# Altium schematic sheet SATA & RGB.SchDoc
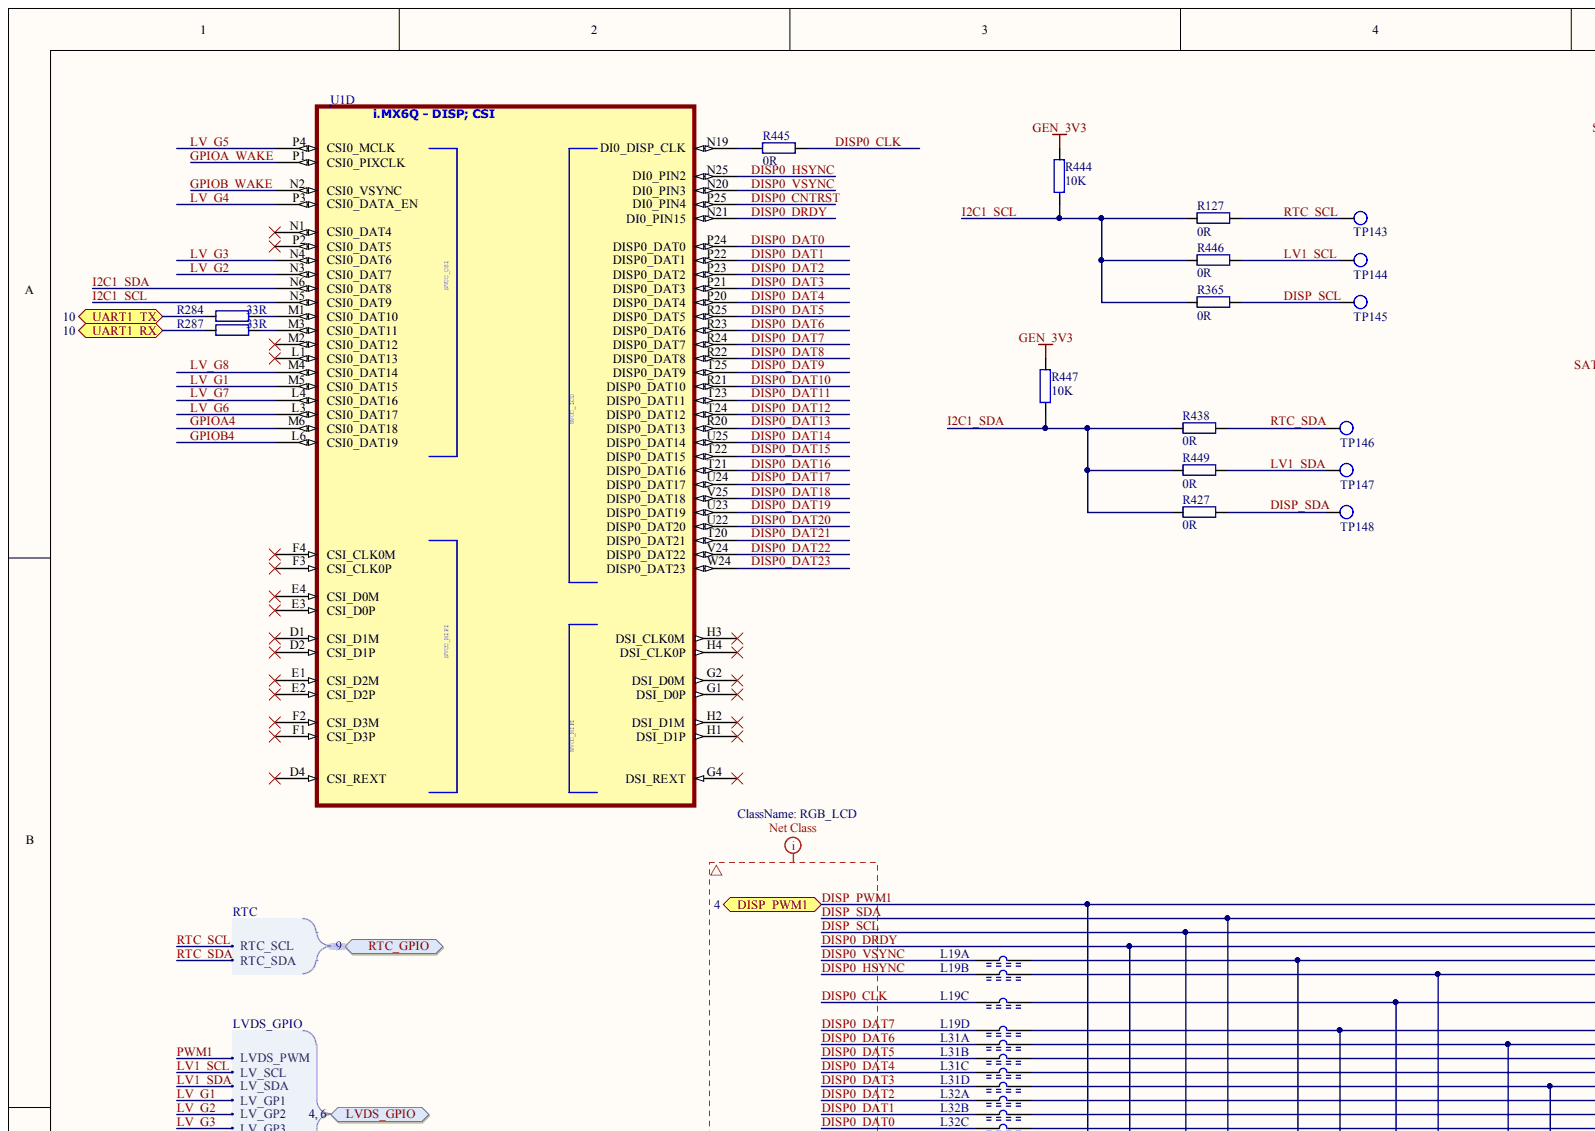
[diagram p0: SATA & RGB.SchDoc, top left]
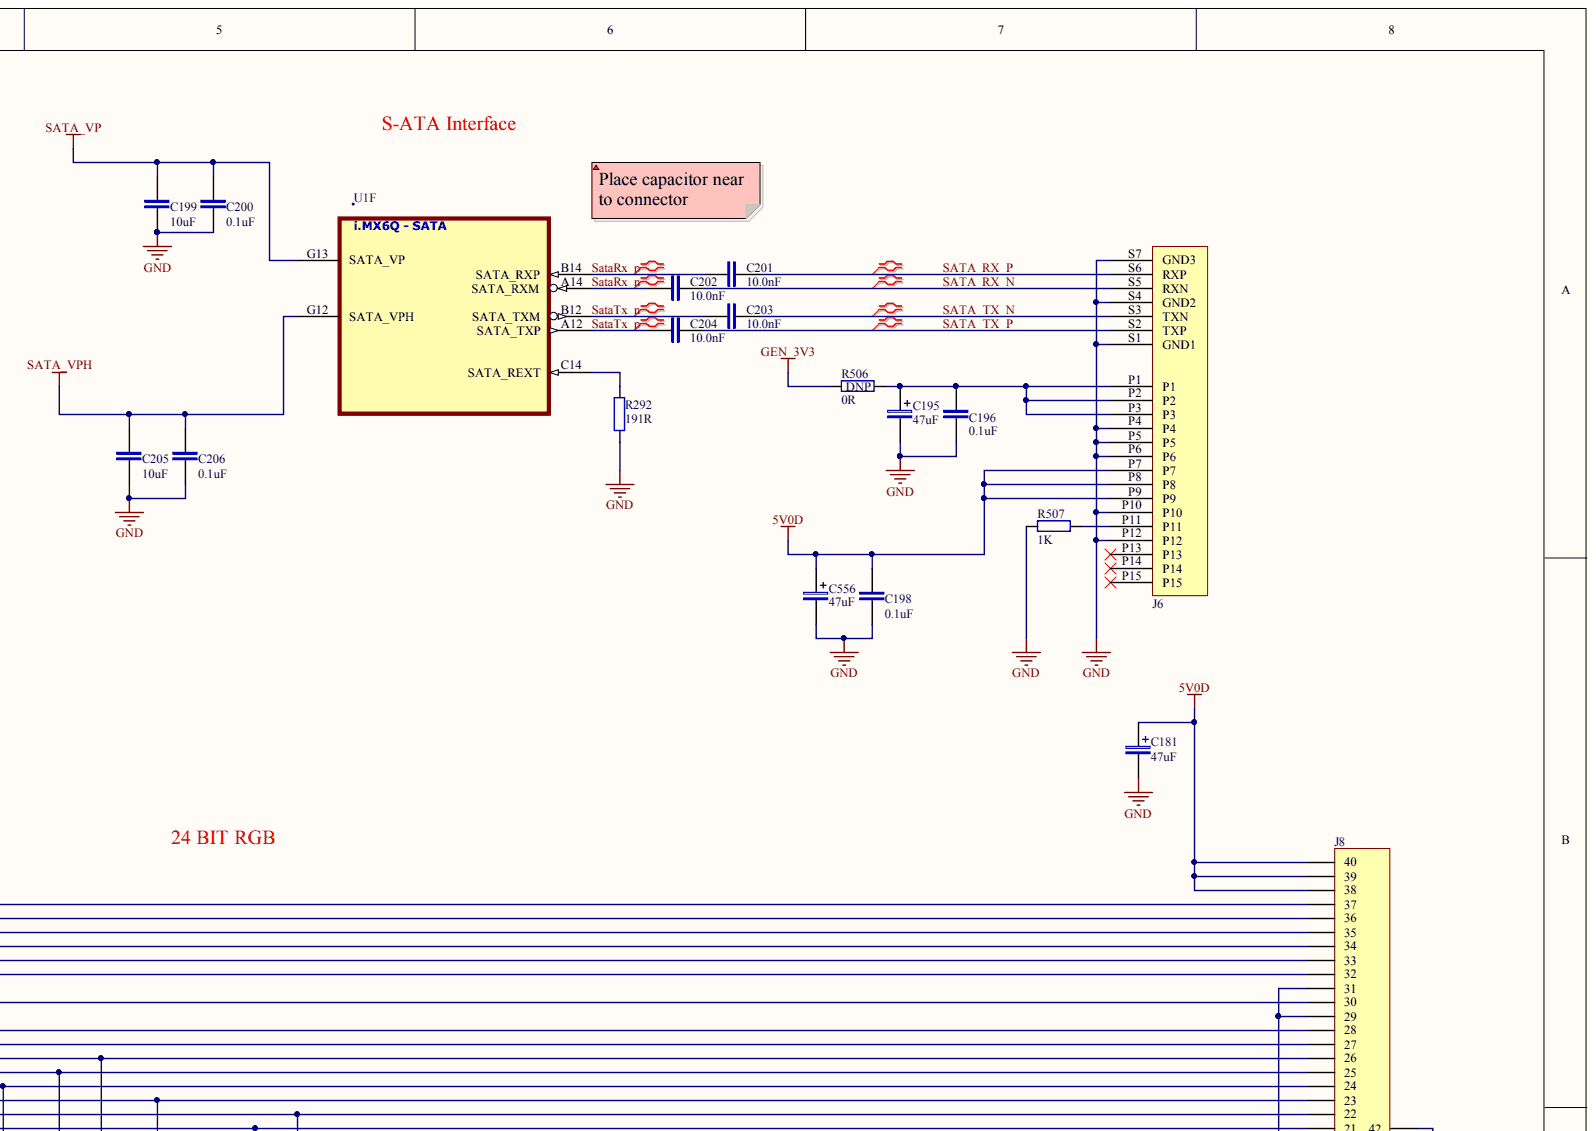
[diagram p1: SATA & RGB.SchDoc, top right]
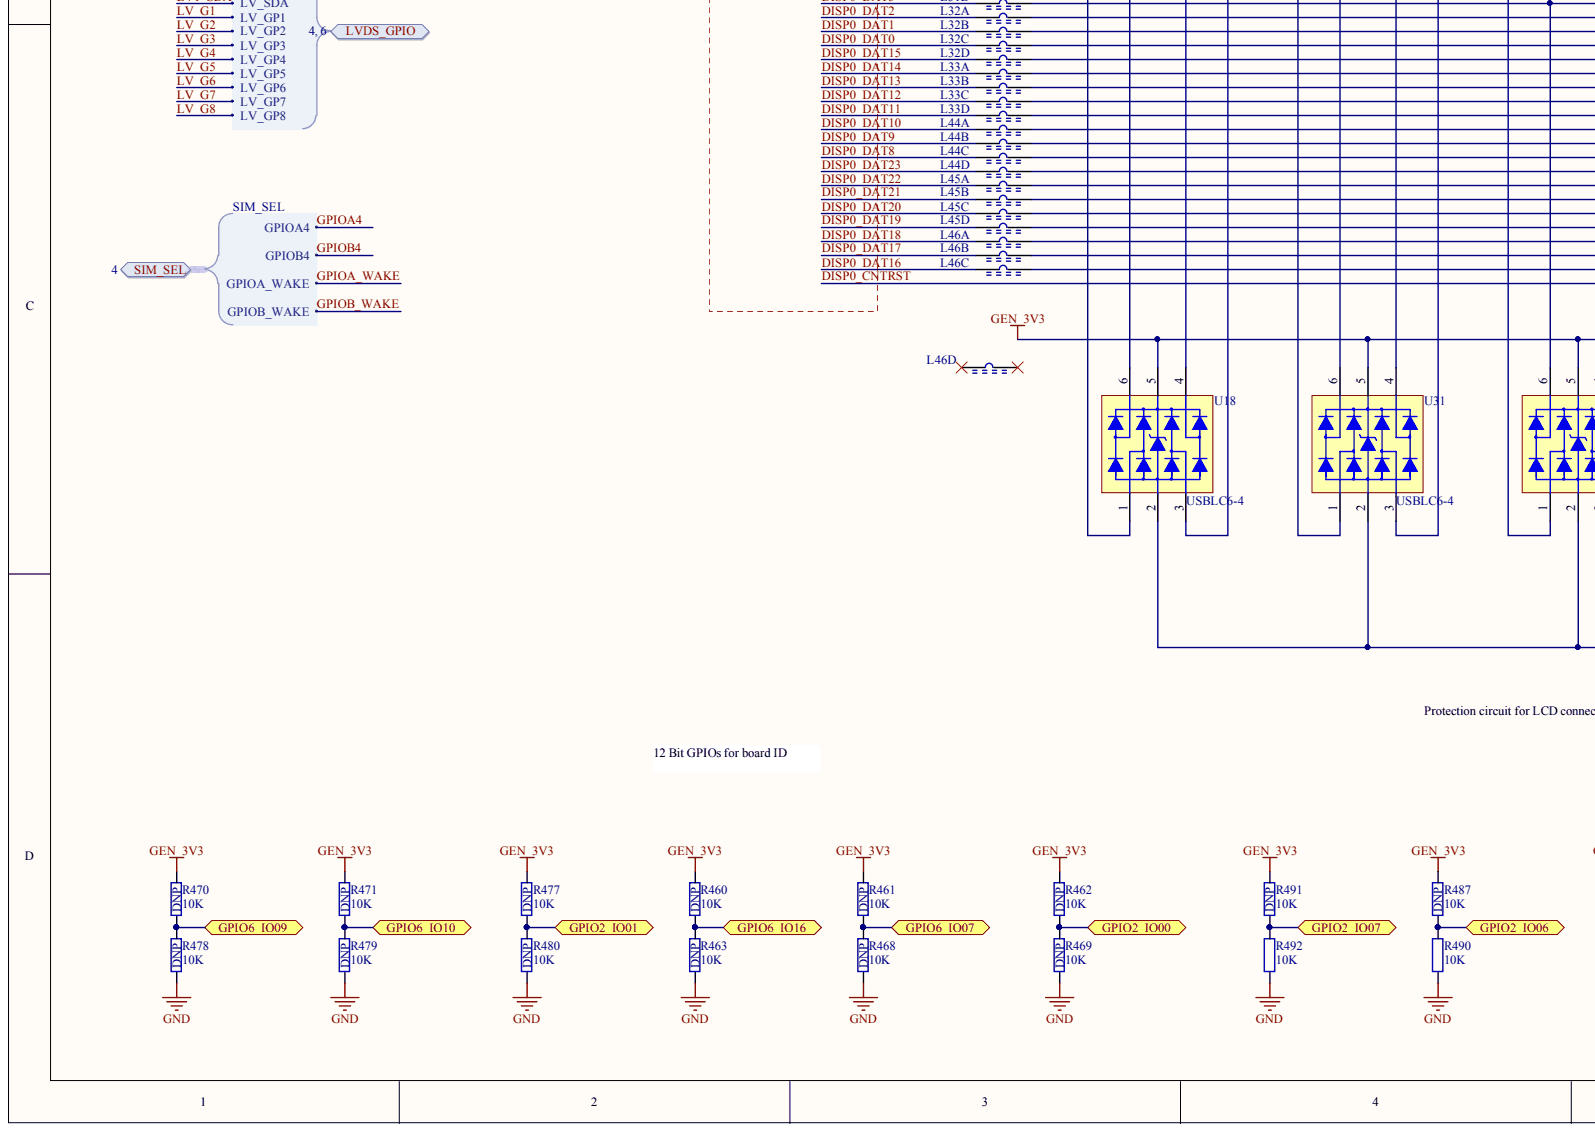
[diagram p2: SATA & RGB.SchDoc, bottom left]
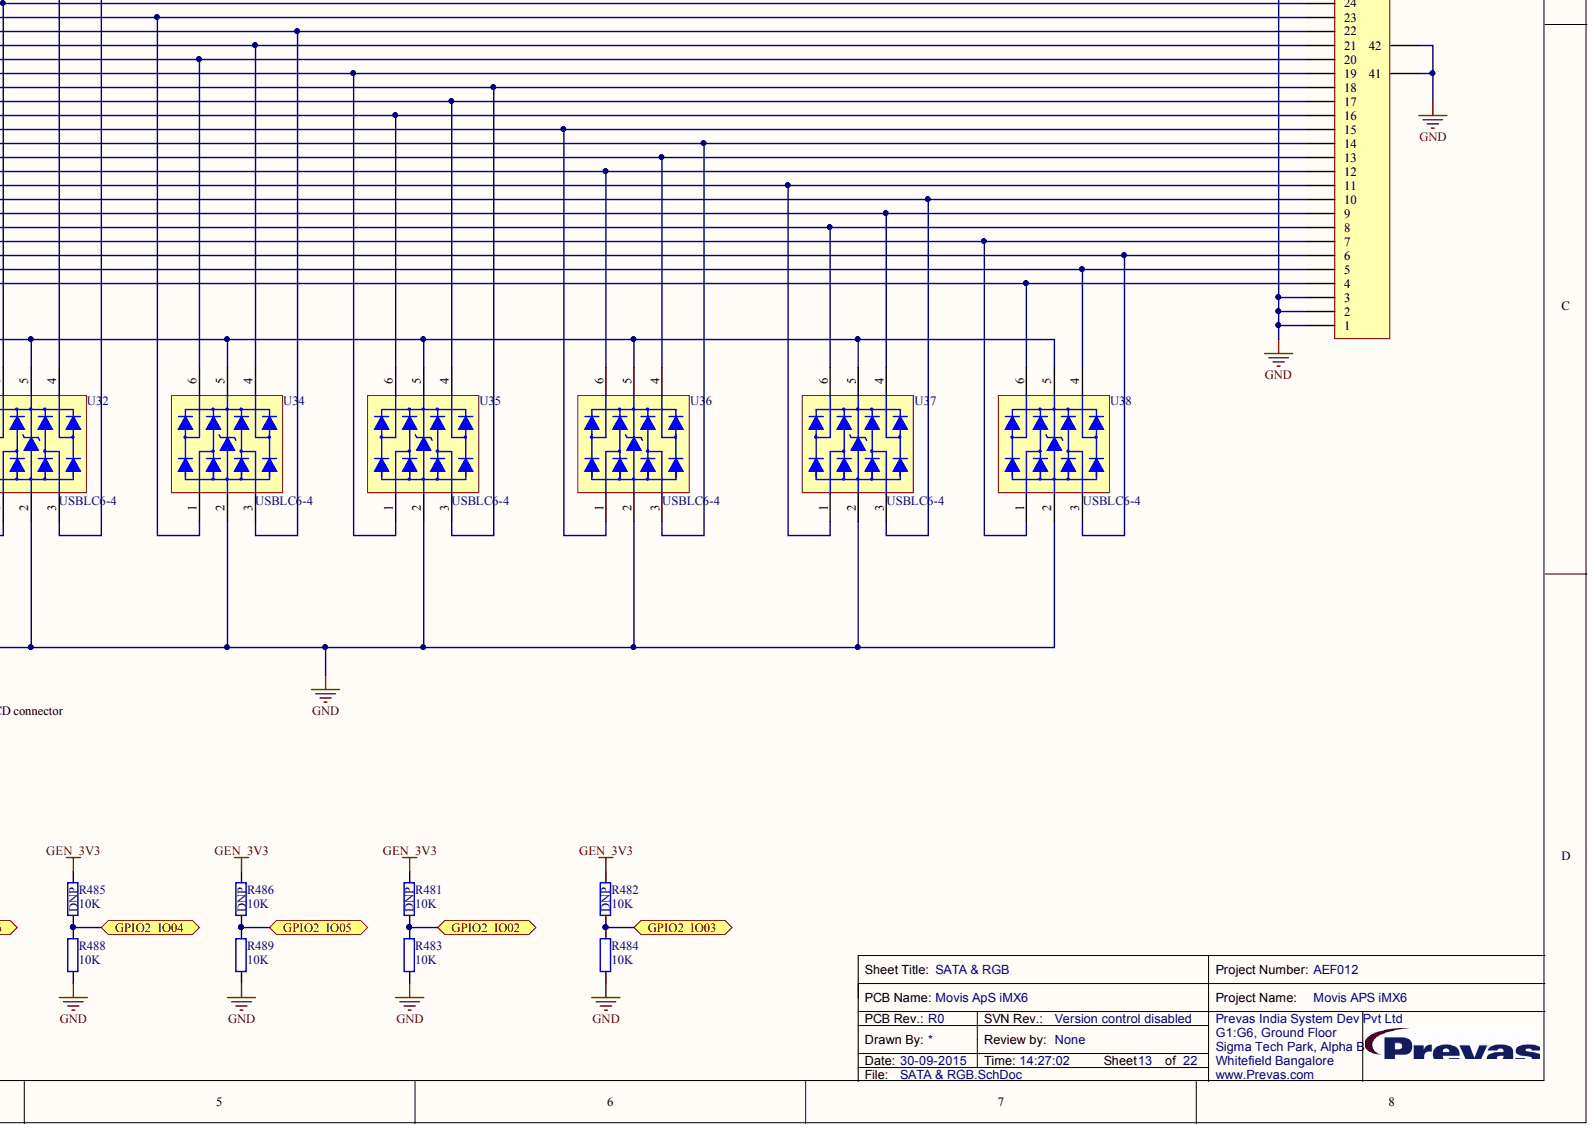
[diagram p3: SATA & RGB.SchDoc, bottom right]

## Parts
C181  47uF  [CAPMP6032X26N]
C195  47uF  [CAPMP6032X26N]
C196  0.1uF  [CAPC1005X55N]
C198  0.1uF  [CAPC1005X55N]
C199  10uF  [CAPC1608X95N]
C200  0.1uF  [CAPC1005X55N]
C201  10.0nF  [CAPC1005X55N]
C202  10.0nF  [CAPC1005X55N]
C203  10.0nF  [CAPC1005X55N]
C204  10.0nF  [CAPC1005X55N]
C205  10uF  [CAPC1608X95N]
C206  0.1uF  [CAPC1005X55N]
C556  47uF  [CAPMP6032X26N]
J6  10029364-001LF  [FCI_10029364-001LF]  (value not drawn)
J8  omron XF2M-4015-1A  [CON40PRA_XF2M-4015-1A]  (value not drawn)
L19  600R@100MHz  [CAPCAF50P100X200X60-8N]  (value not drawn)  (parts A,B,C,D)
L31  600R@100MHz  [CAPCAF50P100X200X60-8N]  (value not drawn)  (parts A,B,C,D)
L32  600R@100MHz  [CAPCAF50P100X200X60-8N]  (value not drawn)  (parts A,B,C,D)
L33  600R@100MHz  [CAPCAF50P100X200X60-8N]  (value not drawn)  (parts A,B,C,D)
L44  600R@100MHz  [CAPCAF50P100X200X60-8N]  (value not drawn)  (parts A,B,C,D)
L45  600R@100MHz  [CAPCAF50P100X200X60-8N]  (value not drawn)  (parts A,B,C,D)
L46  600R@100MHz  [CAPCAF50P100X200X60-8N]  (value not drawn)  (parts A,B,C,D)
R127  0R  [RESC1608X55N]
R284  33R  [RESC1608X55N]
R287  33R  [RESC1608X55N]
R292  191R  [RESC1608X55N]
R365  0R  [RESC1608X55N]
R427  0R  [RESC1608X55N]
R438  0R  [RESC1608X55N]
R444  10K  [RESC1608X55N]
R445  0R  [RESC1608X55N]
R446  0R  [RESC1608X55N]
R447  10K  [RESC1608X55N]
R449  0R  [RESC1608X55N]
R460  10K  Fitted: DNP  [RESC1608X55N]
R461  10K  Fitted: DNP  [RESC1608X55N]
R462  10K  Fitted: DNP  [RESC1608X55N]
R463  10K  Fitted: DNP  [RESC1608X55N]
R468  10K  Fitted: DNP  [RESC1608X55N]
R469  10K  Fitted: DNP  [RESC1608X55N]
R470  10K  Fitted: DNP  [RESC1608X55N]
R471  10K  Fitted: DNP  [RESC1608X55N]
R477  10K  Fitted: DNP  [RESC1608X55N]
R478  10K  Fitted: DNP  [RESC1608X55N]
R479  10K  Fitted: DNP  [RESC1608X55N]
R480  10K  Fitted: DNP  [RESC1608X55N]
R481  10K  Fitted: DNP  [RESC1608X55N]
R482  10K  Fitted: DNP  [RESC1608X55N]
R483  10K  [RESC1608X55N]
R484  10K  [RESC1608X55N]
R485  10K  Fitted: DNP  [RESC1608X55N]
R486  10K  Fitted: DNP  [RESC1608X55N]
R487  10K  Fitted: DNP  [RESC1608X55N]
R488  10K  [RESC1608X55N]
R489  10K  [RESC1608X55N]
R490  10K  [RESC1608X55N]
R491  10K  Fitted: DNP  [RESC1608X55N]
R492  10K  [RESC1608X55N]
R506  0R  Fitted: DNP  [RESC1608X55N]
R507  1K  [RESC1608X55N]
TP143  Testpoint  [TP_SMD]  (value not drawn)  (no BOM)
TP144  Testpoint  [TP_SMD]  (value not drawn)  (no BOM)
TP145  Testpoint  [TP_SMD]  (value not drawn)  (no BOM)
TP146  Testpoint  [TP_SMD]  (value not drawn)  (no BOM)
TP147  Testpoint  [TP_SMD]  (value not drawn)  (no BOM)
TP148  Testpoint  [TP_SMD]  (value not drawn)  (no BOM)
U1  MCIMX6Q6AVT10AC  [BGA624C80P25X25_2100X2100X160]  (value not drawn)  (parts D,F)
U18  USBLC6-4  [SOT23-6L]
U31  USBLC6-4  [SOT23-6L]
U32  USBLC6-4  [SOT23-6L]
U34  USBLC6-4  [SOT23-6L]
U35  USBLC6-4  [SOT23-6L]
U36  USBLC6-4  [SOT23-6L]
U37  USBLC6-4  [SOT23-6L]
U38  USBLC6-4  [SOT23-6L]

## Ports
DISP_PWM1, GPIO2_IO00, GPIO2_IO01, GPIO2_IO02, GPIO2_IO03, GPIO2_IO04, GPIO2_IO05, GPIO2_IO06, GPIO2_IO07, GPIO6_IO07, GPIO6_IO09, GPIO6_IO10, GPIO6_IO16, LVDS_GPIO, LVDS_GPIO.LV_GP1, LVDS_GPIO.LV_GP2, LVDS_GPIO.LV_GP3, LVDS_GPIO.LV_GP4, LVDS_GPIO.LV_GP5, LVDS_GPIO.LV_GP6, LVDS_GPIO.LV_GP7, LVDS_GPIO.LV_GP8, LVDS_GPIO.LV_SCL, LVDS_GPIO.LV_SDA, LVDS_GPIO.LVDS_PWM, RTC_GPIO, RTC_GPIO.RTC_SCL, RTC_GPIO.RTC_SDA, SIM_SEL, SIM_SEL.GPIOA4, SIM_SEL.GPIOA_WAKE, SIM_SEL.GPIOB4, SIM_SEL.GPIOB_WAKE, UART1_RX, UART1_TX

## Nets
5V0D: C181.1 C198.2 C556.1 J6.P7 J6.P8 J6.P9 J8.38 J8.39 J8.40
GEN_3V3: R444.2 R447.2 R460.2 R461.2 R462.2 R470.2 R471.2 R477.2 R481.2 R482.2 R485.2 R486.2 R487.2 R491.2 R506.1 U18.5 U31.5 U32.5 U34.5 U35.5 U36.5 U37.5 U38.5
GND: C181.2 C195.2 C196.1 C198.1 C199.1 C200.1 C205.1 C206.1 C556.2 J6.P4 J6.P5 J6.P6 J6.P10 J6.P12 J6.S1(GND1) J6.S4(GND2) J6.S7(GND3) J8.1 J8.2 J8.3 J8.29 J8.31 J8.41 J8.42 R292.1 R463.1 R468.1 R469.1 R478.1 R479.1 R480.1 R483.1 R484.1 R488.1 R489.1 R490.1 R492.1 R507.2 U18.2 U31.2 U32.2 U34.2 U35.2 U36.2 U37.2 U38.2
SATA_VP: C199.2 C200.2 U1.G13(SATA_VP)
SATA_VPH: C205.2 C206.2 U1.G12(SATA_VPH)
DISP0_CLK: L19.3 R445.2
DISP0_CNTRST: J8.4 U1.P25(DI0_PIN4) U38.6
DISP0_DAT0: L32.3 U1.P24(DISP0_DAT0)
DISP0_DAT1: L32.2 U1.P22(DISP0_DAT1)
DISP0_DAT2: L32.1 U1.P23(DISP0_DAT2)
DISP0_DAT3: L31.4 U1.P21(DISP0_DAT3)
DISP0_DAT4: L31.3 U1.P20(DISP0_DAT4)
DISP0_DAT5: L31.2 U1.R25(DISP0_DAT5)
DISP0_DAT6: L31.1 U1.R23(DISP0_DAT6)
DISP0_DAT7: L19.4 U1.R24(DISP0_DAT7)
DISP0_DAT8: L44.3 U1.R22(DISP0_DAT8)
DISP0_DAT9: L44.2 U1.T25(DISP0_DAT9)
DISP0_DAT10: L44.1 U1.R21(DISP0_DAT10)
DISP0_DAT11: L33.4 U1.T23(DISP0_DAT11)
DISP0_DAT12: L33.3 U1.T24(DISP0_DAT12)
DISP0_DAT13: L33.2 U1.R20(DISP0_DAT13)
DISP0_DAT14: L33.1 U1.U25(DISP0_DAT14)
DISP0_DAT15: L32.4 U1.T22(DISP0_DAT15)
DISP0_DAT16: L46.3 U1.T21(DISP0_DAT16)
DISP0_DAT17: L46.2 U1.U24(DISP0_DAT17)
DISP0_DAT18: L46.1 U1.V25(DISP0_DAT18)
DISP0_DAT19: L45.4 U1.U23(DISP0_DAT19)
DISP0_DAT20: L45.3 U1.U22(DISP0_DAT20)
DISP0_DAT21: L45.2 U1.T20(DISP0_DAT21)
DISP0_DAT22: L45.1 U1.V24(DISP0_DAT22)
DISP0_DAT23: L44.4 U1.W24(DISP0_DAT23)
DISP0_DRDY: J8.34 U1.N21(DI0_PIN15) U18.6
DISP0_HSYNC: L19.2 U1.N25(DI0_PIN2)
DISP0_VSYNC: L19.1 U1.N20(DI0_PIN3)
DISP_PWM1: J8.37 U18.1 ; port DISP_PWM1
DISP_SCL: J8.35 R365.2 TP145.1 U18.4
DISP_SDA: J8.36 R427.2 TP148.1 U18.3
GPIO2_IO00: R462.1 R469.2 ; port GPIO2_IO00
GPIO2_IO01: R477.1 R480.2 ; port GPIO2_IO01
GPIO2_IO02: R481.1 R483.2 ; port GPIO2_IO02
GPIO2_IO03: R482.1 R484.2 ; port GPIO2_IO03
GPIO2_IO04: R485.1 R488.2 ; port GPIO2_IO04
GPIO2_IO05: R486.1 R489.2 ; port GPIO2_IO05
GPIO2_IO06: R487.1 R490.2 ; port GPIO2_IO06
GPIO2_IO07: R491.1 R492.2 ; port GPIO2_IO07
GPIO6_IO07: R461.1 R468.2 ; port GPIO6_IO07
GPIO6_IO09: R470.1 R478.2 ; port GPIO6_IO09
GPIO6_IO10: R471.1 R479.2 ; port GPIO6_IO10
GPIO6_IO16: R460.1 R463.2 ; port GPIO6_IO16
GPIOA4: U1.M6(CSI0_DAT18) ; port SIM_SEL
GPIOA_WAKE: U1.P1(CSI0_PIXCLK) ; port SIM_SEL
GPIOB4: U1.L6(CSI0_DAT19) ; port SIM_SEL
GPIOB_WAKE: U1.N2(CSI0_VSYNC) ; port SIM_SEL
I2C1_SCL: R127.1 R365.1 R444.1 R446.1 U1.N5(CSI0_DAT9)
I2C1_SDA: R427.1 R438.1 R447.1 R449.1 U1.N6(CSI0_DAT8)
LV1_SCL: R446.2 TP144.1 ; port LVDS_GPIO
LV1_SDA: R449.2 TP147.1 ; port LVDS_GPIO
LV_G1: U1.M5(CSI0_DAT15) ; port LVDS_GPIO
LV_G2: U1.N3(CSI0_DAT7) ; port LVDS_GPIO
LV_G3: U1.N4(CSI0_DAT6) ; port LVDS_GPIO
LV_G4: U1.P3(CSI0_DATA_EN) ; port LVDS_GPIO
LV_G5: U1.P4(CSI0_MCLK) ; port LVDS_GPIO
LV_G6: U1.L3(CSI0_DAT17) ; port LVDS_GPIO
LV_G7: U1.L4(CSI0_DAT16) ; port LVDS_GPIO
LV_G8: U1.M4(CSI0_DAT14) ; port LVDS_GPIO
RTC_SCL: R127.2 TP143.1 ; port RTC_GPIO
RTC_SDA: R438.2 TP146.1 ; port RTC_GPIO
SATA_RX_N: C202.1 J6.S5(RXN)
SATA_RX_P: C201.1 J6.S6(RXP)
SATA_TX_N: C203.1 J6.S3(TXN)
SATA_TX_P: C204.1 J6.S2(TXP)
SATARX_N: C202.2 U1.A14(SATA_RXM)
SATARX_P: C201.2 U1.B14(SATA_RXP)
SATATX_N: C203.2 U1.B12(SATA_TXM)
SATATX_P: C204.2 U1.A12(SATA_TXP)
UART1_RX: R287.1 ; port UART1_RX
UART1_TX: R284.1 ; port UART1_TX
NetC195_1: C195.1 C196.2 J6.P1 J6.P2 J6.P3 R506.2
NetJ6_P11: J6.P11 R507.1
NetJ8_5: J8.5 L46.6 U38.4
NetJ8_6: J8.6 L46.7 U38.3
NetJ8_7: J8.7 L46.8 U38.1
NetJ8_8: J8.8 L45.5 U37.6
NetJ8_9: J8.9 L45.6 U37.4
NetJ8_10: J8.10 L45.7 U37.3
NetJ8_11: J8.11 L45.8 U37.1
NetJ8_12: J8.12 L44.5 U36.6
NetJ8_13: J8.13 L44.6 U36.4
NetJ8_14: J8.14 L44.7 U36.3
NetJ8_15: J8.15 L44.8 U36.1
NetJ8_16: J8.16 L33.5 U35.6
NetJ8_17: J8.17 L33.6 U35.4
NetJ8_18: J8.18 L33.7 U35.3
NetJ8_19: J8.19 L33.8 U35.1
NetJ8_20: J8.20 L32.5 U34.6
NetJ8_21: J8.21 L32.6 U34.4
NetJ8_22: J8.22 L32.7 U34.3
NetJ8_23: J8.23 L32.8 U34.1
NetJ8_24: J8.24 L31.5 U32.6
NetJ8_25: J8.25 L31.6 U32.4
NetJ8_26: J8.26 L31.7 U32.3
NetJ8_27: J8.27 L31.8 U32.1
NetJ8_28: J8.28 L19.5 U31.6
NetJ8_30: J8.30 L19.6 U31.4
NetJ8_32: J8.32 L19.7 U31.3
NetJ8_33: J8.33 L19.8 U31.1
NetR284_2: R284.2 U1.M1(CSI0_DAT10)
NetR287_2: R287.2 U1.M3(CSI0_DAT11)
NetR292_2: R292.2 U1.C14(SATA_REXT)
NetR445_1: R445.1 U1.N19(DI0_DISP_CLK)
N00975:
PWM1: ; port LVDS_GPIO

Not connected: J6 P13 P14 P15; L46 4 5; U1 D1(CSI_D1M) D2(CSI_D1P) D4(CSI_REXT) E1(CSI_D2M) E2(CSI_D2P) E3(CSI_D0P) E4(CSI_D0M) F1(CSI_D3P) F2(CSI_D3M) F3(CSI_CLK0P) F4(CSI_CLK0M) G1(DSI_D0P) G2(DSI_D0M) G4(DSI_REXT) H1(DSI_D1P) H2(DSI_D1M) H3(DSI_CLK0M) H4(DSI_CLK0P) L1(CSI0_DAT13) M2(CSI0_DAT12) N1(CSI0_DAT4) P2(CSI0_DAT5)

## Library data of the parts
C181 C195 C556 = INVIHA-51: CAP TANT 47UF 10V 10% 2312; ref_part_type: /capacitor/polarized/ta/; source_manufacturer_1: AVX Corporation; source_manufacturer_1_pn: TAJC476K010RNJ; cae_altium_pcb_status: Prototype; Database Table Name: altium_default; db_rev: 7666; elec_temp_range: -55 to 125°C; phy_height: 2.8mm; phy_material: Tantalum; phy_mounting: SMD; phy_packaging_type: 2312; ref_datasheet: INVIHA-12; ref_keyword: CAPACITOR TANT; cae_altium_sch_status: Prototype; Component Kind: Standard; ComponentLink1Description: Datasheet; ComponentLink1URL: https://cop201.prevas.dk/viewvc/datasheet/INVIHA-12.pdf?root=hw_components&view=co; db_created: 2015-08-05 05:24:04.0; db_last_updated: 05-08-2015 05:24:06; db_local_id: INVIHA-51; db_status: Prototype; elec_tolerance: 10%; elec_value: 47uF; elec_voltage_rating: 10V; Library Name: altium_mysql.dblib
C196 C198 C200 C206 = INNISI-73: CAP CER 0.1UF 50V 10% X5R 0402; ref_part_type: /capacitor/non_polarized/ceramic/; source_manufacturer_1: TDK Corporation; source_manufacturer_1_pn: C1005X5R1H104K050BB; cae_altium_pcb_status: Prototype; Database Table Name: altium_default; db_rev: 7569; elec_temp_range: -55 to +85 C; phy_height: 0.55mm; phy_mounting: SMD; phy_packaging_type: 0402; ref_datasheet: INNISI-71; cae_altium_sch_status: Prototype; Component Kind: Standard; ComponentLink1Description: Datasheet; ComponentLink1URL: https://cop201.prevas.dk/viewvc/datasheet/INNISI-71.pdf?root=hw_components&view=co; db_created: 2015-08-03 03:34:59.0; db_last_updated: 03-08-2015 03:35:01; db_local_id: INNISI-73; db_status: Prototype; elec_tolerance: ±10%; elec_value: 0.1uF; elec_voltage_rating: 50V; phy_material: X5R; ref_keyword: CAP CER 0.1UF 50V 10% X5R 0402; Library Name: altium_mysql.dblib
C199 C205 = innisi-78: CAP CER 10UF 16V 10% X5R 0603; ref_part_type: /capacitor/non_polarized/ceramic/; source_manufacturer_1: Murata; source_manufacturer_1_pn: GRM188R61C106KAALD; cae_altium_pcb_status: Prototype; Database Table Name: altium_default; db_rev: 7574; elec_temp_range: -55 to +85C; phy_height: 0.95mm; phy_mounting: SMD; phy_packaging_type: 0603; ref_datasheet: INNISI-75; ref_keyword: Ceramic capacitor X5R 0603; cae_altium_sch_status: Prototype; Component Kind: Standard; ComponentLink1Description: Datasheet; ComponentLink1URL: https://cop201.prevas.dk/viewvc/datasheet/INNISI-75.pdf?root=hw_components&view=co; db_created: 2015-08-03 05:08:42.0; db_last_updated: 03-08-2015 05:08:44; db_local_id: INNISI-78; db_status: Prototype; elec_tolerance: 10%; elec_value: 10uF; elec_voltage_rating: 16V; phy_material: X5R; Library Name: altium_mysql.dblib
C201-C204 = INNISI-82: CAP CER 10nF 50V 10% X7R 0402; ref_part_type: /capacitor/non_polarized/ceramic/; source_manufacturer_1: Yageo; source_manufacturer_1_pn: CC0402KRX7R9BB103; cae_altium_pcb_status: Prototype; Database Table Name: altium_default; db_rev: 7579; elec_temp_range: -55 to +125C; phy_height: 0.55mm; phy_mounting: SMD; phy_packaging_type: 0402; ref_datasheet: INNISI-72; ref_keyword: Ceramic capacitor; cae_altium_sch_status: Prototype; Component Kind: Standard; ComponentLink1Description: Datasheet; ComponentLink1URL: https://cop201.prevas.dk/viewvc/datasheet/INNISI-72.pdf?root=hw_components&view=co; db_created: 2015-08-03 05:52:48.0; db_last_updated: 03-08-2015 05:52:50; db_local_id: INNISI-82; db_status: Prototype; elec_tolerance: 10%; elec_value: 10.0nF; elec_voltage_rating: 50V; phy_material: X7R; Library Name: altium_mysql.dblib
J6 = INRAAN-226: I/O Connectors COMB REC R/A SMT; ref_part_type: /connector/22 pins/; source_manufacturer_1: FCI; cae_altium_pcb_status: Prototype; Database Table Name: altium_default; db_rev: 7512; elec_current_rating: 1.5 A; elec_temp_range: 260°C max. for 10 Seconds; elec_voltage_rating: 500V AC; phy_height: 5.42mm; phy_mounting: SMD; ref_datasheet: INRAAN-211; ref_keyword: SATA Connector; cae_altium_sch_status: Prototype; Component Kind: Standard; ComponentLink1Description: Datasheet; ComponentLink1URL: https://cop201.prevas.dk/viewvc/datasheet/INRAAN-211.pdf?root=hw_components&view=co; db_created: 2014-12-01 08:45:55.0; db_last_updated: 09-07-2015 05:51:47; db_local_id: INRAAN-226; db_status: Prototype; elec_value: 10029364-001LF; source_manufacturer_1_pn: 10029364-001LF; Library Name: altium_mysql.dblib
J8 = INNISI-13: FFC & FPC Connectors .5mm Rotary BackLock SMT Dual 40P Adhesv.; ref_part_type: /connector/40 pins/; cae_altium_pcb_status: Prototype; Database Table Name: altium_default; db_rev: 7334; elec_current_rating: 500 mA; elec_temp_range: -30 to +85°C; elec_voltage_rating: 50 V; phy_mounting: SMD; ref_keyword: XF2M FFC & FPC Connectors; cae_altium_sch_status: Prototype; Component Kind: Standard; ComponentLink1Description: Datasheet; ComponentLink1URL: https://cop201.prevas.dk/viewvc/datasheet/INNISI-13.pdf?root=hw_components&view=co; db_created: 2015-05-28 05:50:59.0; db_last_updated: 28-05-2015 05:51:19; db_local_id: INNISI-13; db_status: Prototype; elec_value: XF2M-4015-1A; ref_datasheet: INNISI-13; source_manufacturer_1: Omron; source_manufacturer_1_pn: XF2M-4015-1A; Library Name: altium_mysql.dblib
L19 L31-L33 L44-L46 = NIMA-314: Chip EMIFIL Inductor Type Array, 600R@100MHz, 1.1R@0Hz; ref_part_type: /inductor/lossy/; source_manufacturer_1: Murata; source_manufacturer_1_pn: BLA2AAG601SN4D; cae_altium_pcb_status: Prototype; Database Table Name: altium_default; db_rev: 5652; elec_current_rating: 0.05A; elec_frequency_rating: 100MHz; elec_temp_range: -55 to 125; elec_tolerance: 25%; phy_height: 0.6mm; phy_material: Ferrite; phy_mounting: SMD; phy_packaging_type: 4 x 0402 Array; ref_datasheet: GEPW-221; ref_keyword: Ferrite bead 0402x4; cae_altium_sch_status: Prototype; Component Kind: Standard; ComponentLink1Description: Datasheet; ComponentLink1URL: https://cop201.prevas.dk/viewvc/datasheet/GEPW-221.pdf?root=hw_components&view=co; db_created: 2013-04-18 00:50:35.0; db_last_updated: 5/7/2013 4:03:18 PM; db_local_id: NIMA-314; db_status: Prototype; elec_value: 600R@100MHz; Library Name: altium_mysql.dblib
R127 R365 R427 R438 R445 R446 R449 R506 = INVIHA-4: RES SMD 0.0 OHM JUMPER 1/10W; ref_part_type: /resistor/fixed/; source_manufacturer_1: Panasonic Electronic Components; source_manufacturer_1_pn: ERJ-3GEY0R00V; cae_altium_pcb_status: Prototype; Database Table Name: altium_default; db_rev: 7580; elec_power_rating: 0.1W; elec_temp_range: -55 to +155° C; phy_height: 0.55mm; phy_packaging_type: 0603; ref_datasheet: INVIHA-3; ref_keyword: Chip Resistor; cae_altium_sch_status: Prototype; Component Kind: Standard; ComponentLink1Description: Datasheet; ComponentLink1URL: https://cop201.prevas.dk/viewvc/datasheet/INVIHA-3.pdf?root=hw_components&view=co; db_created: 2015-08-03 05:59:19.0; db_last_updated: 03-08-2015 5:59:21 AM; db_local_id: INVIHA-4; db_status: Prototype; elec_value: 0R; phy_mounting: SMD; Library Name: altium_mysql.dblib
R284 R287 = INVIHA-13: RES SMD 33 OHM 1% 1/10W 0603; ref_part_type: /resistor/fixed/; source_manufacturer_1: Yageo; source_manufacturer_1_pn: RC0603FR-0733RL; cae_altium_pcb_status: Prototype; Database Table Name: altium_default; db_rev: 7593; elec_power_rating: 0.1W; elec_temp_range: -55 to +155° C; phy_height: 0.55mm; phy_packaging_type: 0603; ref_datasheet: INVIHA-2; ref_keyword: Chip Resistor; cae_altium_sch_status: Prototype; Component Kind: Standard; ComponentLink1Description: Datasheet; ComponentLink1URL: https://cop201.prevas.dk/viewvc/datasheet/INVIHA-2.pdf?root=hw_components&view=co; db_created: 2015-08-03 06:49:16.0; db_last_updated: 03-08-2015 6:49:17 AM; db_local_id: INVIHA-13; db_status: Prototype; elec_tolerance: 1%; elec_value: 33R; phy_mounting: SMD; Library Name: altium_mysql.dblib
R292 = INVIHA-28: RES SMD 191 OHM 1% 1/10W 0603; ref_part_type: /resistor/fixed/; source_manufacturer_1: Panasonic Electronic Components; source_manufacturer_1_pn: ERJ-3EKF1910V; cae_altium_pcb_status: Prototype; Database Table Name: altium_default; db_rev: 7619; elec_power_rating: 0.1W; elec_temp_range: -55 to +155° C; phy_height: 0.55mm; phy_packaging_type: 0603; ref_datasheet: INVIHA-5; ref_keyword: Chip Resistor; cae_altium_sch_status: Prototype; Component Kind: Standard; ComponentLink1Description: Datasheet; ComponentLink1URL: https://cop201.prevas.dk/viewvc/datasheet/INVIHA-5.pdf?root=hw_components&view=co; db_created: 2015-08-03 08:37:52.0; db_last_updated: 03-08-2015 8:37:54 AM; db_local_id: INVIHA-28; db_status: Prototype; elec_tolerance: 1%; elec_value: 191R; phy_mounting: SMD; Library Name: altium_mysql.dblib
R444 R447 R460-R463 R468-R471 R477-R492 = INVIHA-3: RES SMD 10K OHM 1% 1/10W 0603; ref_part_type: /resistor/fixed/; source_manufacturer_1: Yageo; source_manufacturer_1_pn: RC0603FR-0710KL; cae_altium_pcb_status: Prototype; Database Table Name: altium_default; db_rev: 7575; elec_power_rating: 0.1W; elec_temp_range: -55 to +155° C; phy_height: 0.55mm; phy_packaging_type: 0603; ref_datasheet: INVIHA-2; ref_keyword: Chip Resistor; cae_altium_sch_status: Prototype; Component Kind: Standard; ComponentLink1Description: Datasheet; ComponentLink1URL: https://cop201.prevas.dk/viewvc/datasheet/INVIHA-2.pdf?root=hw_components&view=co; db_created: 2015-08-03 05:39:03.0; db_last_updated: 03-08-2015 5:39:05 AM; db_local_id: INVIHA-3; db_status: Prototype; elec_tolerance: 1%; elec_value: 10K; phy_mounting: SMD; Library Name: altium_mysql.dblib
R507 = INVIHA-11: RES SMD 1K OHM 1% 1/10W 0603; ref_part_type: /resistor/fixed/; source_manufacturer_1: Yageo; source_manufacturer_1_pn: RC0603FR-071KL; cae_altium_pcb_status: Prototype; Database Table Name: altium_default; db_rev: 7590; elec_power_rating: 0.1W; elec_temp_range: -55 to +155° C; phy_height: 0.55mm; phy_packaging_type: 0603; ref_datasheet: INVIHA-2; ref_keyword: Chip Resistor; cae_altium_sch_status: Prototype; Component Kind: Standard; ComponentLink1Description: Datasheet; ComponentLink1URL: https://cop201.prevas.dk/viewvc/datasheet/INVIHA-2.pdf?root=hw_components&view=co; db_created: 2015-08-03 06:39:44.0; db_last_updated: 03-08-2015 6:39:46 AM; db_local_id: INVIHA-11; db_status: Prototype; elec_tolerance: 1%; elec_value: 1K; phy_mounting: SMD; Library Name: altium_mysql.dblib
TP143-TP148 = JEPE-114: Test Point SMD; ref_part_type: /pcb_item/; cae_altium_pcb_status: Prototype; Database Table Name: altium_default; db_rev: 3281; cae_altium_sch_status: Prototype; ComponentLink1Description: Datasheet; db_created: 2008-08-18 11:39:42; db_last_updated: 23-03-2011 14:14:06; db_local_id: JEPE-114; db_status: Prototype; elec_value: Test Point; phy_mounting: SMD; ref_keyword: Test  Point; Library Name: altium_mysql.dblib
U1 = INRAAN-5: i.MX 6Dual/6Quad; ref_part_type: /integrated/digital/processor/general/; AGILE_PN: 344-01218; CAD_APPROVED: Yes; cae_altium_pcb_status: Prototype; CLASS: IC; Database Table Name: altium_default; db_rev: 6247; elec_temp_range: -40 to +105; elec_voltage_rating: 1.5V; MFG_NAME01: FREESCALE SEMICONDUCTOR; phy_height: 1.85; phy_mounting: SMD; phy_packaging_type: FCPBGA96_624; ref_datasheet: INRAAN-6; cae_altium_sch_status: Prototype; Component Kind: Standard; ComponentLink1Description: Datasheet; ComponentLink1URL: https://cop201.prevas.dk/viewvc/datasheet/INRAAN-6.pdf?root=hw_components&view=co; db_created: 2013-10-21 12:25:57.0; db_last_updated: 27-12-2013 09:32:57; db_local_id: INRAAN-5; db_status: Prototype; elec_value: MCIMX6Q6AVT10AC; HEIGHT: 2.16MM; MFG_PN01: MCIMX6Q6AVT10AC; ref_keyword: i.MX 6Dual/6Quad; ROHS: Yes; source_manufacturer_1: Freescale Semiconductor; source_manufacturer_1_pn: MCIMX6Q6AVT10AC; Library Name: altium_mysql.dblib
U18 U31 U32 U34-U38 = NIMA-155: Dual very low capacitance ESD protection for USB 2.0 up to 480Mb/s; ref_part_type: /diode/transient/; source_manufacturer_1: STMicroelectronics; cae_altium_pcb_status: Prototype; Database Table Name: altium_default; db_rev: 1817; elec_temp_range: -40 to 125; elec_voltage_rating: 5V; phy_height: 1.45mm; phy_mounting: SMD; ref_datasheet: NIMA-115; cae_altium_sch_status: Prototype; Component Kind: Standard; ComponentLink1Description: Datasheet; ComponentLink1URL: https://cop201.prevas.dk/viewvc/datasheet/NIMA-115.pdf?root=hw_components&view=co; db_created: 2009-09-25 21:20:59; db_last_updated: 9/25/2009 9:21:20 PM; db_local_id: NIMA-155; db_status: Prototype; elec_value: USBLC6-4; phy_packaging_type: SOT23-6L; ref_keyword: ESD; source_manufacturer_1_pn: USBLC6-4; Library Name: altium_mysql.dblib
